# Altium schematic sheet RaspberryDAC.SchDoc(RaspberryDAC)
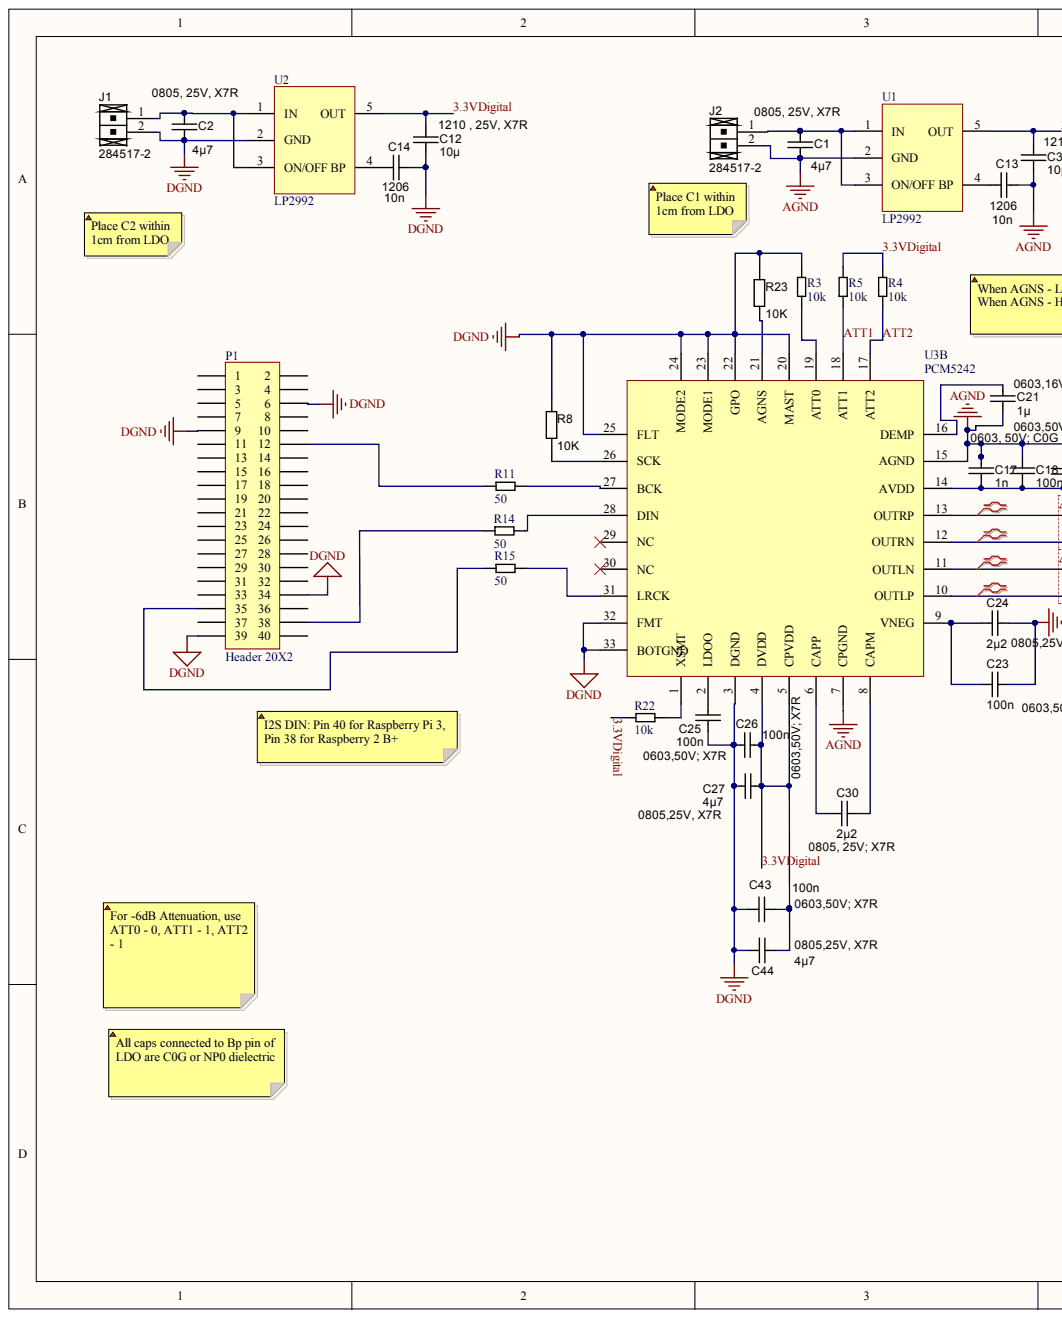
[diagram p0: RaspberryDAC.SchDoc, left]
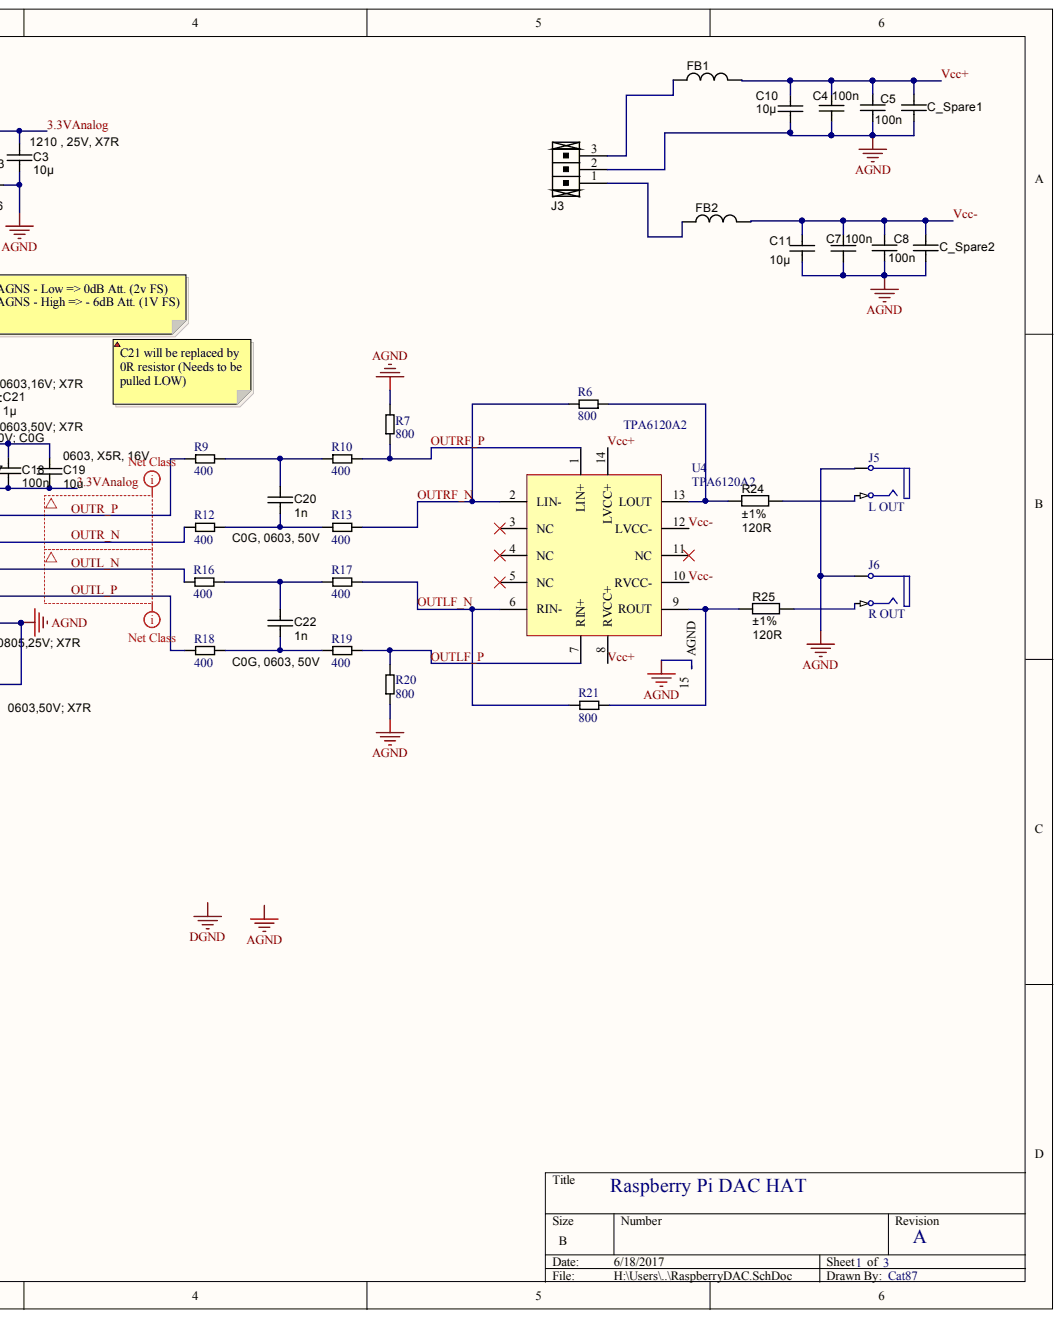
[diagram p1: RaspberryDAC.SchDoc, right]

## Parts
C1  value 4µ7  (hidden comment: approved sub OK)
C2  value 4µ7  (hidden comment: approved sub OK)
C3  10µ
C4  value 100n  (hidden comment: approved sub OK)
C5  value 100n  (hidden comment: approved sub OK)
C7  value 100n  (hidden comment: approved sub OK)
C8  value 100n  (hidden comment: approved sub OK)
C10  10µ
C11  10µ
C12  10µ
C13  Package / Case: 1206  (hidden comment: 10n)
C14  Package / Case: 1206  (hidden comment: 10n)
C17  value 1n  (hidden comment: approved sub OK)
C18  value 100n  (hidden comment: approved sub OK)
C19  value 10u  (hidden comment: approved sub OK)
C20  C0G, 0603, 50V  value 1n
C21  value 1µ  (hidden comment: approved sub OK)
C22  C0G, 0603, 50V  value 1n
C23  value 100n  (hidden comment: approved sub OK)
C24  value 2µ2  (hidden comment: approved sub OK)
C25  value 100n  (hidden comment: approved sub OK)
C26  value 100n  (hidden comment: approved sub OK)
C27  value 4µ7  (hidden comment: approved sub OK)
C30  value 2µ2  Package / Case: 0805, 25V; X7R  (hidden comment: approved sub OK)
C43  value 100n  (hidden comment: approved sub OK)
C44  value 4µ7  (hidden comment: approved sub OK)
C_Spare1  approved sub OK  (value not drawn)
C_Spare2  approved sub OK  (value not drawn)
FB1  2K2 @ 100 MHz  (value not drawn)
FB2  2K2 @ 100 MHz  (value not drawn)
J1  Manufacturer Part Number 1: 284517-2  (hidden comment: 2P_TERM_BLOCK_HEADER)
J2  Manufacturer Part Number 1: 284517-2  (hidden comment: 2P_TERM_BLOCK_HEADER)
J3  3P_TERM BLOCK HEADER  (value not drawn)
J5  L OUT
J6  R OUT
P1  Header 20X2
R3  10k
R4  10k
R5  10k
R6  800
R7  800
R8  value 10K  (hidden comment: Thick Film)
R9  400
R10  400
R11  50
R12  400
R13  400
R14  50
R15  50
R16  400
R17  400
R18  400
R19  400
R20  800
R21  800
R22  10k
R23  value 10K  (hidden comment: Thick Film)
R24  value 120R  Tolerance: ±1%  (hidden comment: Thick Film)
R25  value 120R  Tolerance: ±1%  (hidden comment: Thick Film)
U1  LP2992
U2  LP2992
U3  PCM5242  (part B)
U3A  PCM5242
U4  TPA6120A2

## Nets
AGND: C1.2 C3.2 C4.2 C5.2 C7.2 C8.2 C10.2 C11.2 C13.2 C17.1 C18.1 C19.1 C21.1 C23.2 C24.2 C_Spare1.2 C_Spare2.2 J2.2 J3.2 J5.1A J6.1A R7.2 R20.1 U1.2(GND) U3.7(CPGND) U3.15(AGND) U4.15(AGND)
DGND: C2.2 C12.2 C14.2 C25.1 C26.1 C27.1 C43.1 C44.1 J1.2 P1.6 P1.9 P1.34 P1.39 R3.2 R8.1 R23.1 U2.2(GND) U3.3(DGND) U3.20(MAST) U3.22(GPO) U3.23(MODE1) U3.24(MODE2) U3.25(FLT) U3.32(FMT) U3.33(BOTGND)
3.3VANALOG: C3.1 C17.2 C18.2 C19.2 U1.5(OUT) U3.14(AVDD)
3.3VDIGITAL: C12.1 C26.2 C27.2 C43.2 C44.2 R4.2 R5.2 R22.2 U2.5(OUT) U3.4(DVDD) U3.5(CPVDD)
ATT1: R5.1 U3.18(ATT1)
ATT2: R4.1 U3.17(ATT2)
OUTL_N: R16.1 U3.11(OUTLN)
OUTL_P: R18.1 U3.10(OUTLP)
OUTLF_N: R17.2 R21.2 U4.6(RIN-)
OUTLF_P: R19.2 R20.2 U4.7(RIN+)
OUTR_N: R12.1 U3.12(OUTRN)
OUTR_P: R9.1 U3.13(OUTRP)
OUTRF_N: R6.2 R13.2 U4.2(LIN-)
OUTRF_P: R7.1 R10.2 U4.1(LIN+)
VCC+: C4.1 C5.1 C10.1 C_Spare1.1 FB1.2 U4.8(RVCC+) U4.14(LVCC+)
VCC-: C7.1 C8.1 C11.1 C_Spare2.1 FB2.2 U4.10(RVCC-) U4.12(LVCC-)
NetC1_1: C1.1 J2.1 U1.1(IN) U1.3(ON/OFF)
NetC2_1: C2.1 J1.1 U2.1(IN) U2.3(ON/OFF)
NetC13_1: C13.1 U1.4(BP)
NetC14_1: C14.1 U2.4(BP)
NetC20_1: C20.1 R9.2 R10.1
NetC20_2: C20.2 R12.2 R13.1
NetC21_2: C21.2 U3.16(DEMP)
NetC22_1: C22.1 R16.2 R17.1
NetC22_2: C22.2 R18.2 R19.1
NetC23_1: C23.1 C24.1 U3.9(VNEG)
NetC25_2: C25.2 U3.2(LDOO)
NetC30_1: C30.1 U3.6(CAPP)
NetC30_2: C30.2 U3.8(CAPM)
NetFB1_1: FB1.1 J3.3
NetFB2_1: FB2.1 J3.1
NetJ5_2B: J5.2B R24.2
NetJ6_2B: J6.2B R25.2
NetP1_12: P1.12 R11.1
NetP1_35: P1.35 R15.1
NetP1_38: P1.38 R14.1
NetR3_1: R3.1 U3.19(ATT0)
NetR6_1: R6.1 R24.1 U4.13(LOUT)
NetR8_2: R8.2 U3.26(SCK)
NetR11_2: R11.2 U3.27(BCK)
NetR14_2: R14.2 U3.28(DIN)
NetR15_2: R15.2 U3.31(LRCK)
NetR21_1: R21.1 R25.1 U4.9(ROUT)
NetR22_1: R22.1 U3.1(XSMT)
NetR23_2: R23.2 U3.21(AGNS)
N00102:
N00103:

Not connected: P1 1 2 3 4 5 7 8 10 11 13 14 15 16 17 18 19 20 21 22 23 24 25 26 27 28 29 30 31 32 33 36 37 40; U3 29(NC) 30(NC); U4 3(NC) 4(NC) 5(NC) 11(NC)

## Library data of the parts
C1 C27 = (no symbol name): Manufacturer 1: Taiyo Yuden; Manufacturer Part Number 1: EMK212BJ475KG-T; Tolerance: ±10%; Ratings: 16V; X5R; Material: ceramic; SCDType: spec; Supplier 1: Digi-Key; Supplier Part Number 1: 587-1294-1-ND; Operating Temperature: -55°C ~ 85°C; Size / Dimension: 0.079" L x 0.049" W (2.00mm x 1.25mm); Thickness (Max): 0.053" (1.35mm); ComponentLink1URL: http://www.yuden.co.jp/productdata/catalog/en/mlcc01_e.pdf; ComponentLink1Description: http://www.yuden.co.jp/productdata/catalog/en/mlcc01_e.pdf; Supplier 2: Digi-Key; Supplier Part Number 2: 1276-1065-1-ND; Manufacturer 2: Samsung Electro-Mechanics America, Inc; Manufacturer Part Number 2: CL21A475KOFNNNE; RoHS 2: RoHS Compliant; ComponentLink2URL: http://www.samsungsem.com/servlet/FileDownload?type=spec&file=CL21A475KOFNNNE.pdf; ComponentLink2Description: http://www.samsungsem.com/servlet/FileDownload?type=spec&file=CL21A475KOFNNNE.pdf; Supplier 3: Digi-Key; Supplier Part Number 3: 399-8101-1-ND; Manufacturer 3: Kemet; Manufacturer Part Number 3: C0805C475K4PACTU; RoHS 3: RoHS Compliant; ComponentLink3URL: http://capacitoredge.kemet.com/capedge2/DataSheet?pn=C0805C475K4PACTU; ComponentLink3Description: http://capacitoredge.kemet.com/capedge2/DataSheet?pn=C0805C475K4PACTU; Supplier 4: Digi-Key; Supplier Part Number 4: 490-7215-1-ND; Manufacturer 4: Murata Electronics North America; Manufacturer Part Number 4: GRM21BR61C475MA88L; RoHS 4: RoHS Compliant; ComponentLink4URL: http://psearch.murata.com/capacitor/product/GRM21BR61C475MA88#.pdf; ComponentLink4Description: http://psearch.murata.com/capacitor/product/GRM21BR61C475MA88#.pdf; Supplier 5: Digi-Key; Supplier Part Number 5: 445-7640-1-ND; Manufacturer 5: TDK Corporation; Manufacturer Part Number 5: C2012X5R1C475K125AC; RoHS 5: RoHS Compliant; ComponentLink5URL: http://product.tdk.com/capacitor/mlcc/en/documents/mlcc_commercial_general_en.pdf; ComponentLink5Description: http://product.tdk.com/capacitor/mlcc/en/documents/mlcc_commercial_general_en.pdf; RoHS 1: RoHS Compliant
  C1: Package / Case: 0805, 25V, X7R
  C27: Package / Case: 0805,25V, X7R
C2 = (no symbol name): Manufacturer 1: Taiyo Yuden; Manufacturer Part Number 1: EMK212BJ475KG-T; Tolerance: ±10%; Ratings: 25V, X7R; Material: ceramic; SCDType: spec; Package / Case: 0805, 25V, X7R; Supplier 1: Digi-Key; Supplier Part Number 1: 587-1294-1-ND; Operating Temperature: -55°C ~ 85°C; Size / Dimension: 0.079" L x 0.049" W (2.00mm x 1.25mm); Thickness (Max): 0.053" (1.35mm); ComponentLink1URL: http://www.yuden.co.jp/productdata/catalog/en/mlcc01_e.pdf; ComponentLink1Description: http://www.yuden.co.jp/productdata/catalog/en/mlcc01_e.pdf; Supplier 2: Digi-Key; Supplier Part Number 2: 1276-1065-1-ND; Manufacturer 2: Samsung Electro-Mechanics America, Inc; Manufacturer Part Number 2: CL21A475KOFNNNE; RoHS 2: RoHS Compliant; ComponentLink2URL: http://www.samsungsem.com/servlet/FileDownload?type=spec&file=CL21A475KOFNNNE.pdf; ComponentLink2Description: http://www.samsungsem.com/servlet/FileDownload?type=spec&file=CL21A475KOFNNNE.pdf; Supplier 3: Digi-Key; Supplier Part Number 3: 399-8101-1-ND; Manufacturer 3: Kemet; Manufacturer Part Number 3: C0805C475K4PACTU; RoHS 3: RoHS Compliant; ComponentLink3URL: http://capacitoredge.kemet.com/capedge2/DataSheet?pn=C0805C475K4PACTU; ComponentLink3Description: http://capacitoredge.kemet.com/capedge2/DataSheet?pn=C0805C475K4PACTU; Supplier 4: Digi-Key; Supplier Part Number 4: 490-7215-1-ND; Manufacturer 4: Murata Electronics North America; Manufacturer Part Number 4: GRM21BR61C475MA88L; RoHS 4: RoHS Compliant; ComponentLink4URL: http://psearch.murata.com/capacitor/product/GRM21BR61C475MA88#.pdf; ComponentLink4Description: http://psearch.murata.com/capacitor/product/GRM21BR61C475MA88#.pdf; Supplier 5: Digi-Key; Supplier Part Number 5: 445-7640-1-ND; Manufacturer 5: TDK Corporation; Manufacturer Part Number 5: C2012X5R1C475K125AC; RoHS 5: RoHS Compliant; ComponentLink5URL: http://product.tdk.com/capacitor/mlcc/en/documents/mlcc_commercial_general_en.pdf; ComponentLink5Description: http://product.tdk.com/capacitor/mlcc/en/documents/mlcc_commercial_general_en.pdf; RoHS 1: RoHS Compliant
C3 C10-C12 = (no symbol name): Manufacturer 1: Taiyo Yuden; Manufacturer Part Number 1: UMK325BJ106MM-T; Tolerance: ±20%; Ratings: 50V; X5R; Material: ceramic; SCDType: spec; Size / Dimension: 0.126" L x 0.098" W (3.20mm x 2.50mm); Supplier 1: Digi-Key; Supplier Part Number 1: 587-2225-1-ND; Value: 10µ
  C3 C12: Package / Case: 1210 , 25V, X7R
  C10 C11: Package / Case: 1210 (3225 Metric)
C4 C5 C7 C8 C18 C23 C25 C26 C43 = (no symbol name): Manufacturer 1: AVX Corporation; Manufacturer Part Number 1: 06035C104KAT2A; Tolerance: ±10%; Ratings: 50V; X7R; Material: ceramic; SCDType: spec; Manufacturer 2: TDK Corporation; Manufacturer Part Number 2: C1608X7R1H104K; Supplier 1: Digi-Key; Supplier Part Number 1: 478-5052-1-ND; ComponentLink1URL: http://www.avx.com/docs/Catalogs/cx7r.pdf; ComponentLink1Description: http://www.avx.com/docs/Catalogs/cx7r.pdf; Supplier 2: Digi-Key; Supplier Part Number 2: 445-1314-1-ND; ComponentLink2URL: http://product.tdk.com/capacitor/mlcc/en/documents/mlcc_commercial_general_en.pdf; ComponentLink2Description: http://product.tdk.com/capacitor/mlcc/en/documents/mlcc_commercial_general_en.pdf
  C4 C5 C7 C8: Package / Case: 0603 (1608 Metric)
  C18 C23 C25 C26 C43: Package / Case: 0603,50V; X7R
C13 C14 = (no symbol name): Manufacturer 1: TDK Corporation; Manufacturer Part Number 1: C3216C0G1H104J160AA; SCDType: undef; Tolerance: ±5%; Ratings: 25V, C0G; Operating Temperature: -55°C ~ 125°C; Size / Dimension: 0.126" L x 0.063" W (3.20mm x 1.60mm); Thickness (Max): 0.075" (1.90mm); Supplier 1: Digi-Key; Supplier Part Number 1: 445-7694-1-ND; ComponentLink1URL: http://product.tdk.com/capacitor/mlcc/en/documents/mlcc_commercial_general_en.pdf; ComponentLink1Description: http://product.tdk.com/capacitor/mlcc/en/documents/mlcc_commercial_general_en.pdf; Value: 10n
C17 C20 C22 = (no symbol name): Manufacturer 1: Murata Electronics North America; Manufacturer Part Number 1: GCM188R72A102KA37D; Tolerance: ±10%; Ratings: 100V; X7R; Material: ceramic; SCDType: spec; Supplier 1: Digi-Key; Supplier Part Number 1: 490-4780-1-ND; Operating Temperature: -55°C ~ 125°C; Size / Dimension: 0.063" L x 0.031" W (1.60mm x 0.80mm); Thickness (Max): 0.035" (0.90mm); ComponentLink1URL: http://search.murata.co.jp/Ceramy/image/img/PDF/ENG/GCM188R72A102KA37.pdf; ComponentLink1Description: http://search.murata.co.jp/Ceramy/image/img/PDF/ENG/GCM188R72A102KA37.pdf
  C17: Package / Case: 0603, 50V; C0G
  C20 C22: Package / Case: 0603 (1608 metric)
C19 = (no symbol name): Manufacturer 1: TDK Corporation; Manufacturer Part Number 1: C1608X7R1C224K; Tolerance: ±10%; Ratings: 16V; X7R; SCDType: undef; Package / Case: 0603, X5R, 16V; Supplier 1: Digi-Key; Supplier Part Number 1: 445-1318-1-ND
C21 = (no symbol name): Manufacturer 1: TDK Corporation; Manufacturer Part Number 1: C1608X7R1C105K; Tolerance: ±10%; Ratings: 16V; X7R; Material: ceramic; SCDType: spec; Package / Case: 0603,16V; X7R; Supplier 1: Digi-Key; Supplier Part Number 1: 445-1604-1-ND; ComponentLink1URL: http://product.tdk.com/capacitor/mlcc/en/documents/mlcc_commercial_general_en.pdf; ComponentLink1Description: http://product.tdk.com/capacitor/mlcc/en/documents/mlcc_commercial_general_en.pdf
C24 C30 = (no symbol name): Manufacturer 1: Kemet; Manufacturer Part Number 1: C0805C225K4RACTU; Tolerance: ±10%; Ratings: 16V; X7R; SCDType: undef; Supplier 1: Digi-Key; Supplier Part Number 1: 399-3523-1-ND
  C24: Package / Case: 0805,25V; X7R
C44 = (no symbol name): Ratings: 16V; X5R; Package / Case: 0805,25V, X7R; Size / Dimension: 0805
C_Spare1 C_Spare2 = (no symbol name): Manufacturer 1: Taiyo Yuden; Manufacturer Part Number 1: EMK212BJ475KG-T; Tolerance: ±10%; Ratings: 16V; X5R; SCDType: spec; Value: 4µ7; Package / Case: 0805 (2012 Metric); Material: ceramic; Supplier 1: Digi-Key; Supplier Part Number 1: 587-1294-1-ND; Operating Temperature: -55°C ~ 85°C; Size / Dimension: 0.079" L x 0.049" W (2.00mm x 1.25mm); Thickness (Max): 0.053" (1.35mm); ComponentLink1URL: http://www.yuden.co.jp/productdata/catalog/en/mlcc01_e.pdf; ComponentLink1Description: http://www.yuden.co.jp/productdata/catalog/en/mlcc01_e.pdf; Supplier 2: Digi-Key; Supplier Part Number 2: 1276-1065-1-ND; Manufacturer 2: Samsung Electro-Mechanics America, Inc; Manufacturer Part Number 2: CL21A475KOFNNNE; RoHS 2: RoHS Compliant; ComponentLink2URL: http://www.samsungsem.com/servlet/FileDownload?type=spec&file=CL21A475KOFNNNE.pdf; ComponentLink2Description: http://www.samsungsem.com/servlet/FileDownload?type=spec&file=CL21A475KOFNNNE.pdf; Supplier 3: Digi-Key; Supplier Part Number 3: 399-8101-1-ND; Manufacturer 3: Kemet; Manufacturer Part Number 3: C0805C475K4PACTU; RoHS 3: RoHS Compliant; ComponentLink3URL: http://capacitoredge.kemet.com/capedge2/DataSheet?pn=C0805C475K4PACTU; ComponentLink3Description: http://capacitoredge.kemet.com/capedge2/DataSheet?pn=C0805C475K4PACTU; Supplier 4: Digi-Key; Supplier Part Number 4: 490-7215-1-ND; Manufacturer 4: Murata Electronics North America; Manufacturer Part Number 4: GRM21BR61C475MA88L; RoHS 4: RoHS Compliant; ComponentLink4URL: http://psearch.murata.com/capacitor/product/GRM21BR61C475MA88#.pdf; ComponentLink4Description: http://psearch.murata.com/capacitor/product/GRM21BR61C475MA88#.pdf; Supplier 5: Digi-Key; Supplier Part Number 5: 445-7640-1-ND; Manufacturer 5: TDK Corporation; Manufacturer Part Number 5: C2012X5R1C475K125AC; RoHS 5: RoHS Compliant; ComponentLink5URL: http://product.tdk.com/capacitor/mlcc/en/documents/mlcc_commercial_general_en.pdf; ComponentLink5Description: http://product.tdk.com/capacitor/mlcc/en/documents/mlcc_commercial_general_en.pdf; RoHS 1: RoHS Compliant
FB1 FB2 = (no symbol name): Manufacturer 1: TDK Corporation; Manufacturer Part Number 1: PBY160808T-601Y; Ratings: 200 mA; 800 mOhm DC; Impedance @ Frequency: 2200 Ohm @ 100MHz; Current Rating: 200mA; DC Resistance (DCR): 800 mOhm Max; Filter Type: Differential Mode - Single; SCDType: source; Size / Dimension: 0.063" L x 0.031" W (1.60mm x 0.80mm); Package / Case: 0603 (1608 Metric); Height (Max): 0.037" (0.95mm); Mounting Type: Surface Mount; Supplier 1: Digi-Key; Supplier Part Number 1: 445-8671-1-ND; RoHS 1: RoHS Compliant; ComponentLink1URL: http://www.tdk.co.jp/tefe02/e9412_mmz.pdf; ComponentLink1Description: http://www.tdk.co.jp/tefe02/e9412_mmz.pdf; Value: 2K2 @ 100 MHz
J1 J2 = (no symbol name): Manufacturer 1: TE Connectivity; Pitch: 0.150" (3.81mm); Voltage: 300V; Positions Per Level: 2; Mating Connector: 284507-2; SCDType: undef; Category: Connectors; Mounting Type: Through Hole; Terminal Block Type: Header, Male Pins, Right-angle, Shrouded (4 Side); Package / Case: Header; 3.81mm pitch; Supplier 1: Digi-Key; Supplier Part Number 1: A98410-ND
J3 = (no symbol name): Manufacturer 1: TE Connectivity; Manufacturer Part Number 1: 284517-3; Pitch: 0.150" (3.81mm); Voltage: 300V; Mating Connector: 284507-3; Value: 3 position; Positions Per Level: 3; SCDType: undef; Category: Connectors; Mounting Type: Through Hole; Terminal Block Type: Header, Male Pins, Shrouded (4 Side); Package / Case: Side Entry; 3.81mm pitch; Supplier 1: Digi-Key; Supplier Part Number 1: A98411-ND; ComponentLink1URL: http://www.te.com/commerce/DocumentDelivery/DDEController?Action=srchrtrv&DocNm=284517&DocType=Customer+Drawing&DocLang=English; ComponentLink1Description: http://www.te.com/commerce/DocumentDelivery/DDEController?Action=srchrtrv&DocNm=284517&DocType=Customer+Drawing&DocLang=English
J5 J6 = (no symbol name): PCB_Layout: Complies with manufacturer's recommendation.; DrillAndSlotSize: Corresponds to nominal value.; Published: 15-Jul-2004; LatestRevisionDate: 15-Jul-2004; LatestRevisionNote: Component Replaced.; DatasheetDocument: 7-Jul-2000; PackageDocument: 7-Jul-2000; PackageReference: 161; ComponentLink1Description: Manufacturer Link; ComponentLink1URL: http://www.mouser.com/kobiconn/; Publisher: Altium Limited
R8 R23 = (no symbol name): Manufacturer 1: Yageo; Manufacturer Part Number 1: RC0603FR-071KL; Ratings: 1/10W; Tolerance: ±1%; SCDType: spec; Package / Case: 0603 (1608 Metric); Supplier 1: Digi-Key; Supplier Part Number 1: 311-1.00KHRCT-ND
R24 R25 = (no symbol name): Manufacturer 1: Vishay/Dale; Manufacturer Part Number 1: CRCW08051K80FKEA; Ratings: 1/8W; SCDType: spec; Package / Case: 0805 (2012 metric); Supplier 1: Digi-Key; Supplier Part Number 1: 541-1.80KCCT-ND
P1 R3-R7 R9-R22 U1-U3 U3A U4 = (no symbol name)
  P1: LatestRevisionDate: 17-Jul-2002; LatestRevisionNote: Re-released for DXP Platform.; Publisher: Altium Limited
  U4: Name: TPA6120A2
